# Altium schematic sheet MCU.SchDoc
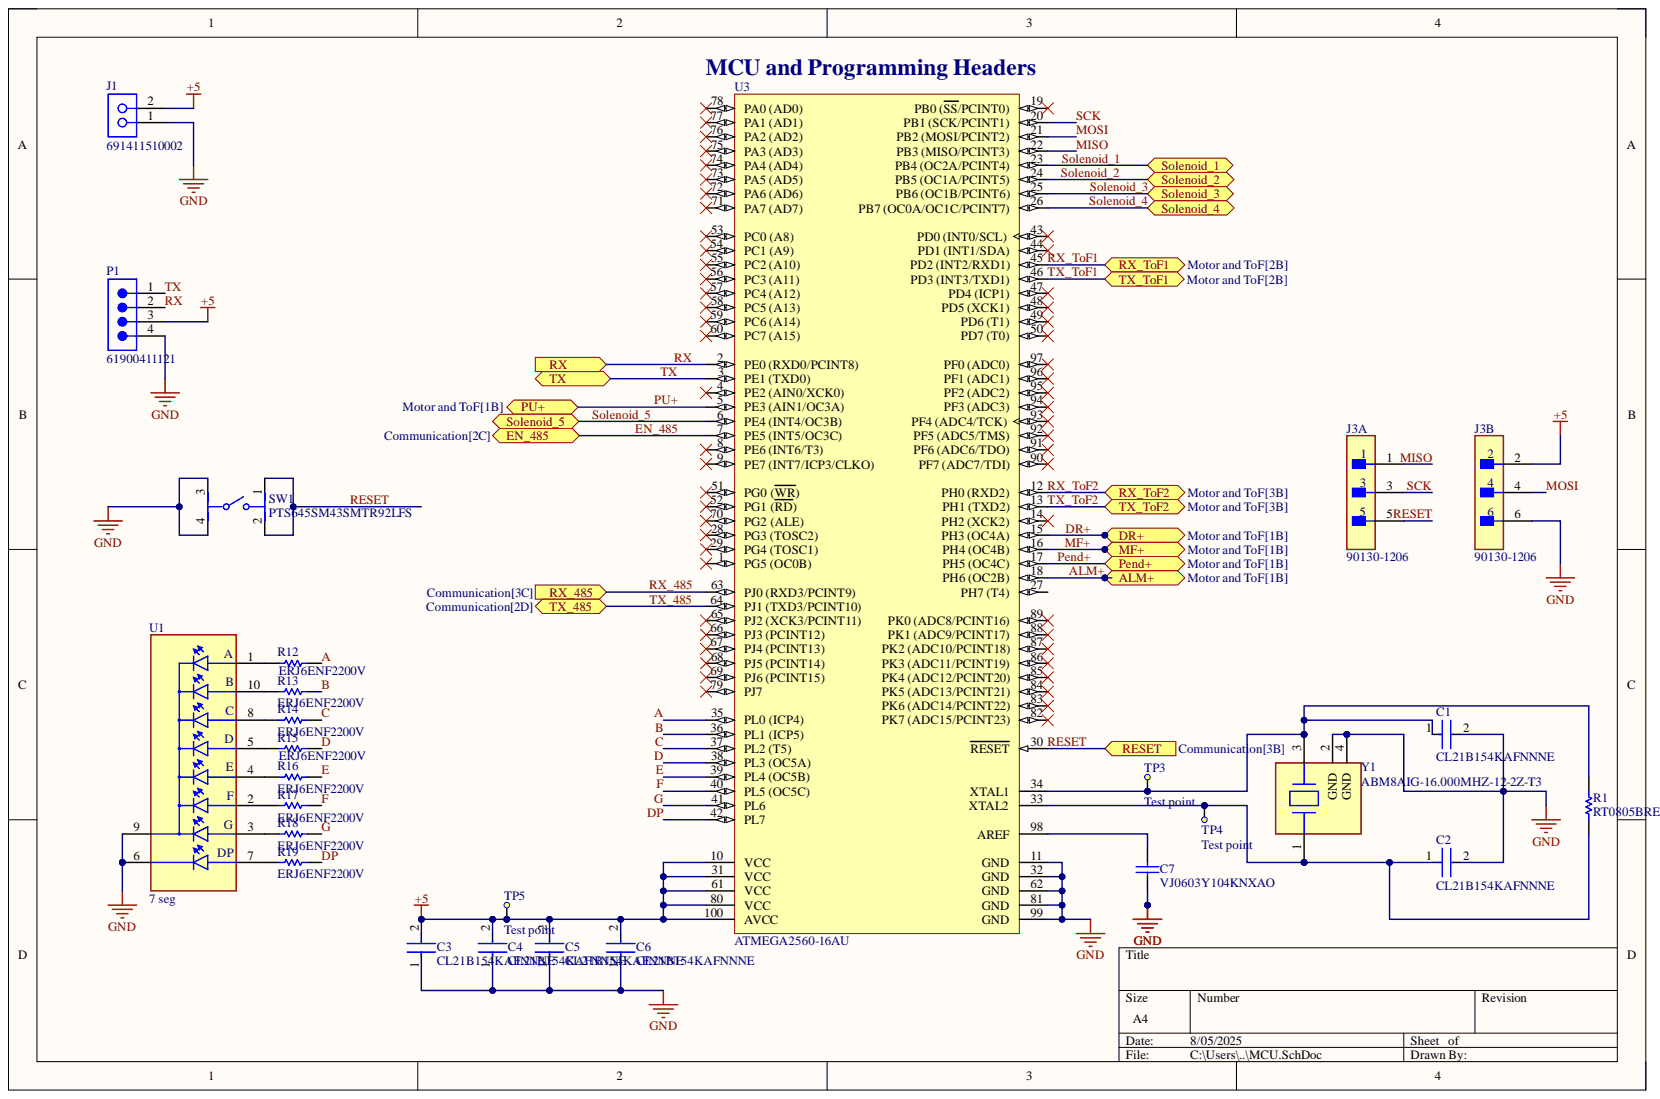
[diagram p0: MCU.SchDoc]

## Parts
C1  CL21B154KAFNNNE  [FP-CL21-IPC_C]
C2  CL21B154KAFNNNE  [FP-CL21-IPC_C]
C3  CL21B154KAFNNNE  [FP-CL21-IPC_C]
C4  CL21B154KAFNNNE  [FP-CL21-IPC_C]
C5  CL21B154KAFNNNE  [FP-CL21-IPC_C]
C6  CL21B154KAFNNNE  [FP-CL21-IPC_C]
C7  VJ0603Y104KNXAO  [CAPC1608X92X38LL15T08]
J1  691411510002  [691411510002]
J3  90130-1206  [FP-90130-1206-MFG]  (parts A,B)
P1  61900411121  [61900411121]
R1  RT0805BRE071ML  [RESC2013X60X35ML10T20]  (value not drawn)
R12  ERJ6ENF2200V  [RESC2013X70X40NL20T20]
R13  ERJ6ENF2200V  [RESC2013X70X40NL20T20]
R14  ERJ6ENF2200V  [RESC2013X70X40NL20T20]
R15  ERJ6ENF2200V  [RESC2013X70X40NL20T20]
R16  ERJ6ENF2200V  [RESC2013X70X40NL20T20]
R17  ERJ6ENF2200V  [RESC2013X70X40NL20T20]
R18  ERJ6ENF2200V  [RESC2013X70X40NL20T20]
R19  ERJ6ENF2200V  [RESC2013X70X40NL20T20]
SW1  PTS645SM43SMTR92LFS  [FP-PTS645SM43SMTR92LFS-MFG]
TP3  Test point  [Test_point]
TP4  Test point  [Test_point]
TP5  Test point  [Test_point]
U1  7 seg  [LED_HDSP-U113]
U3  ATMEGA2560-16AU  [ATML-100A_L]
Y1  ABM8AIG-16.000MHZ-12-2Z-T3  [FP-ABM8AIG-MFG]

## Ports
ALM+, DR+, EN_485, MF+, Pend+, PU+, RESET, RX, RX_485, RX_ToF1, RX_ToF2, Solenoid_1, Solenoid_2, Solenoid_3, Solenoid_4, Solenoid_5, TX, TX_485, TX_ToF1, TX_ToF2

## Nets
+5: C3.2 C4.2 C5.2 C6.2 J1.2 J3.2 P1.3 TP5.TP? U3.10(VCC) U3.31(VCC) U3.61(VCC) U3.80(VCC) U3.100(AVCC)
GND: C1.2 C2.2 C3.1 C4.1 C5.1 C6.1 C7.1 J1.1 J3.6 P1.4 SW1.3 SW1.4 U1.6 U1.9 U3.11(GND) U3.32(GND) U3.62(GND) U3.81(GND) U3.99(GND) Y1.2(GND) Y1.4(GND)
A: R12.2 U3.35(PL0_(ICP4))
ALM+: U3.18(PH6_(OC2B)) ; port ALM+
B: R13.2 U3.36(PL1_(ICP5))
C: R14.2 U3.37(PL2_(T5))
D: R15.2 U3.38(PL3_(OC5A))
DP: R19.2 U3.42(PL7)
DR+: U3.15(PH3_(OC4A)) ; port DR+
E: R16.2 U3.39(PL4_(OC5B))
EN_485: U3.7(PE5_(INT5/OC3C)) ; port EN_485
F: R17.2 U3.40(PL5_(OC5C))
G: R18.2 U3.41(PL6)
MF+: U3.16(PH4_(OC4B)) ; port MF+
MISO: J3.1 U3.22(PB3_(MISO/PCINT3))
MOSI: J3.4 U3.21(PB2_(MOSI/PCINT2))
Pend+: U3.17(PH5_(OC4C)) ; port Pend+
PU+: U3.5(PE3_(AIN1/OC3A)) ; port PU+
RESET: J3.5 SW1.1 SW1.2 U3.30(RESET) ; port RESET
RX: P1.2 U3.2(PE0_(RXD0/PCINT8)) ; port RX
RX_485: U3.63(PJ0_(RXD3/PCINT9)) ; port RX_485
RX_ToF1: U3.45(PD2_(INT2/RXD1)) ; port RX_ToF1
RX_ToF2: U3.12(PH0_(RXD2)) ; port RX_ToF2
SCK: J3.3 U3.20(PB1_(SCK/PCINT1))
Solenoid_1: U3.23(PB4_(OC2A/PCINT4)) ; port Solenoid_1
Solenoid_2: U3.24(PB5_(OC1A/PCINT5)) ; port Solenoid_2
Solenoid_3: U3.25(PB6_(OC1B/PCINT6)) ; port Solenoid_3
Solenoid_4: U3.26 ; port Solenoid_4
Solenoid_5: U3.6(PE4_(INT4/OC3B)) ; port Solenoid_5
TX: P1.1 U3.3(PE1_(TXD0)) ; port TX
TX_485: U3.64(PJ1_(TXD3/PCINT10)) ; port TX_485
TX_ToF1: U3.46(PD3_(INT3/TXD1)) ; port TX_ToF1
TX_ToF2: U3.13(PH1_(TXD2)) ; port TX_ToF2
NetC1_1: C1.1 R1.2 TP3.TP? U3.34(XTAL1) Y1.3
NetC2_1: C2.1 R1.1 TP4.TP? U3.33(XTAL2) Y1.1
NetC7_2: C7.2 U3.98(AREF)
NetR12_1: R12.1 U1.1
NetR13_1: R13.1 U1.10
NetR14_1: R14.1 U1.8
NetR15_1: R15.1 U1.5
NetR16_1: R16.1 U1.4
NetR17_1: R17.1 U1.2
NetR18_1: R18.1 U1.3
NetR19_1: R19.1 U1.7

Not connected: U3 1(PG5_(OC0B)) 4(PE2_(AIN0/XCK0)) 8(PE6_(INT6/T3)) 9(PE7_(INT7/ICP3/CLKO)) 14(PH2_(XCK2)) 19(PB0_(SS/PCINT0)) 27(PH7_(T4)) 28(PG3_(TOSC2)) 29(PG4_(TOSC1)) 43(PD0_(INT0/SCL)) 44(PD1_(INT1/SDA)) 47(PD4_(ICP1)) 48(PD5_(XCK1)) 49(PD6_(T1)) 50(PD7_(T0)) 51(PG0_(WR)) 52(PG1_(RD)) 53(PC0_(A8)) 54(PC1_(A9)) 55(PC2_(A10)) 56(PC3_(A11)) 57(PC4_(A12)) 58(PC5_(A13)) 59(PC6_(A14)) 60(PC7_(A15)) 65(PJ2_(XCK3/PCINT11)) 66(PJ3_(PCINT12)) 67(PJ4_(PCINT13)) 68(PJ5_(PCINT14)) 69(PJ6_(PCINT15)) 70(PG2_(ALE)) 71(PA7_(AD7)) 72(PA6_(AD6)) 73(PA5_(AD5)) 74(PA4_(AD4)) 75(PA3_(AD3)) 76(PA2_(AD2)) 77(PA1_(AD1)) 78(PA0_(AD0)) 79(PJ7) 82(PK7_(ADC15/PCINT23)) 83(PK6_(ADC14/PCINT22)) 84(PK5_(ADC13/PCINT21)) 85(PK4_(ADC12/PCINT20)) 86(PK3_(ADC11/PCINT19)) 87(PK2_(ADC10/PCINT18)) 88(PK1_(ADC9/PCINT17)) 89(PK0_(ADC8/PCINT16)) 90(PF7_(ADC7/TDI)) 91(PF6_(ADC6/TDO)) 92(PF5_(ADC5/TMS)) 93(PF4_(ADC4/TCK)) 94(PF3_(ADC3)) 95(PF2_(ADC2)) 96(PF1_(ADC1)) 97(PF0_(ADC0))

## Library data of the parts
C1-C6 = CMP-13271-001271-1: CL21 Series 0805 150nF 25V ±10% Tolerance X7R Multilayer Ceramic Chip Capacitor; Capacitance: 150nF; Case Code (Imperial): 0805; Case Code (Metric): 2012; Case/Package: 0805; Component Kind: Standard; Depth: 1.25mm; Dielectric: X7R; Height: 1.25mm; Lead Free: Lead Free; Length: 2mm; Library Name: Altium Content Vault; Max Operating Temperature: 125°C; Min Operating Temperature: -55°C; Mount: Surface Mount; Package Quantity: 1; Packaging: Tape and Reel; Radiation Hardening: No; Thickness: 0.053inch; Tolerance: 10%; Voltage Rating: 25V; Voltage Rating (DC): 25V; Width: 0.049inch
C7 = CMP-1035-02588-2: Manufacturer: Vitramon; Component Kind: Standard; Library Name: Altium Content Vault; Part Number: VJ0603Y104KNXAO
J1 = CMP-1502-03078-2: NexarPartId: 105952432; Current Rating: 10A; Number of Contacts: 2; Voltage Rating: 300V; Component Kind: Standard; Height: 14mm; Library Name: Altium Content Vault; Max Operating Temperature: 120°C; Min Operating Temperature: -30°C; Number of Rows: 1
J3 = CMP-04776-000468-1: CONN HEADER VERT 6POS 2.54MM; Contact Resistance: 20mR; Current Rating: 3A; Gender: Male; Glow Wire Compliant: Non-compliant; Insulation Resistance: 5GR; Max Current Rating: 3.9A; Max Voltage Rating (AC): 500V; Max Voltage Rating (DC): 350.35kV; Number of Circuits: 6; Voltage Rating: 350V; Color: Black; Component Kind: Standard; Contact Gender: Male; Contact Material: Brass; Contact Plating: Gold; Depth: 3.94mm; Height: 4.7mm; Housing Color: Black; Housing Material: Polyester; Lead Free: Lead Free; Lead Pitch: 2.54mm; Length: 8mm; Library Name: Altium Content Vault; Material: Glass; Max Operating Temperature: 125°C; Min Operating Temperature: -55°C; Mount: Through Hole; Number of Contacts: 6; Number of Poles: 6; Number of Positions: 6; Number of Rows: 2; Orientation: Vertical; Package Quantity: 2560; Packaging: Tray; Pitch: 2.54mm; Radiation Hardening: No; Row Spacing: 2.54mm; Series: 90130; Termination: Solder; Voltage Rating (AC): 350V; Voltage Rating (DC): 350V; Working Voltage: 350V
P1 = CMP-1502-00586-2: NexarPartId: 18818226; Connector Type: Male Pin; Contact Resistance: 20mΩ; Current Rating: 3A; Gender: Male; Insulation: Insulated; Insulation Resistance: 1GΩ; Number of Contacts: 4; Operating Supply Voltage: 250V; Pitch: 2.54mm; Color: Beige; Component Kind: Standard; Contact Gender: Male; Contact Material: Copper; Contact Mating Length: 7.493mm; Contact Plating: Tin; Fastening Type: Friction; Flammability Rating: UL94 V-0; Height: 3.3mm; Housing Material: Nylon; Lead Free: Lead Free; Lead Pitch: 2.54mm; Length: 10.16mm; Library Name: Altium Content Vault; Max Operating Temperature: 105°C; Min Operating Temperature: -40°C; Mount: Through Hole; Number of Pins: 4; Number of Positions: 4; Number of Rows: 1; Orientation: Vertical; Packaging: Bulk; REACH SVHC: Unknown; RoHS: Compliant; Termination: Through Hole; Voltage Rating: 250V; Width: 10.2mm
R1 = CMP-2001-01491-1: Manufacturer: Yageo; Component Kind: Standard; Library Name: Altium Content Vault; Part Number: RT0805BRE071ML
R12-R19 = CMP-2001-00044-1: Manufacturer: Panasonic; Component Kind: Standard; Library Name: Altium Content Vault; Part Number: ERJ6ENF2200V
SW1 = CMP-2000-05160-2: SWITCH TACTILE SPST-NO 0.05A 12V; Actuator Length: 0.85mm; Actuator Material: Nylon; Actuator Type: Standard; Contact Resistance: 100mR; Current Rating: 50mA; Illumination Type: Non-Illuminated; Mechanical Life: 100000Cycles; Operating Force: 160gf; Switch Function: Off-Mom; Throw Configuration: SPST; Case/Package: SMD/SMT; Color: Black; Component Kind: Standard; Contact Material: Silver; Contact Plating: Silver; Depth: 9mm; Lead Free: Lead Free; Length: 6mm; Library Name: Altium Content Vault; Max Current Rating: 50mA; Max Operating Temperature: 60°C; Max Voltage Rating (DC): 12V; Min Operating Temperature: -20°C; Mount: Surface Mount; Orientation: Straight; Package Quantity: 1; Packaging: Tape and Reel; REACH SVHC: No SVHC; Termination: Gull Wing; Voltage Rating (DC): 12V
TP3-TP5 = test_point: Component Kind: Standard; Library Name: SL1.SchLib; Value: Test point
U1 = HDSP-U113: Display Modules - LED Character and Numeric Red 7-Segment 1 Character Common Cathode 1.7V 5mA 0.433 H x 0.280 W x 0.197 D (11.00mm x 7.10mm x 5.00mm) 10-DIP (0.209, 5.30mm); MF: Avago; Availability: In Stock; Check_prices: https://www.snapeda.com/parts/HDSP-U113/Avago/view-part/?ref=eda; Component Kind: Standard; Library Name: HDSP-U113.IntLib; MP: HDSP-U113; Package: None; Price: None; SnapEDA_Link: https://www.snapeda.com/parts/HDSP-U113/Avago/view-part/?ref=snap
U3 = CMP-020-00013-3: Atmel Microcontroller with 256 KB In-System Programmable Flash, 8-Bit , 4.5 to 5.5 V, -40 to 85 degC, 100-Pin QFP, Pb-Free, Tape and Reel; ADC Channels: 16; Density: 256KB; Ethernet Channels: 0; Frequency: 16MHz; I/Os: 86; Interface: USART; Max Supply Voltage: 5.5V; Min Supply Voltage: 4.5V; Nominal Supply Voltage: 5V; PWM Channels: 12; RAM Size: 8KB; Case/Package: TQFP; Component Kind: Standard; Datasheet URL: http://www.atmel.com/images/atmel-2549-8-bit-avr-microcontroller-atmega640-1280-1281-2560-2561_summary.pdf; Datasheet Version: Feb-14; Manufacturer: Atmel; Manufacturer URL: http://www.atmel.com/; Max Clock Frequency: 16MHz; Max Operating Temperature: 85°C; Min Operating Temperature: -40°C; Mounting Technology: SM; Package Description: 100-Pin Thin Profile Plastic Quad Flat Package, Body 14 x 14 mm, Pitch 0.5 mm; Package Quantity: 90; Package Reference: 100A; Packaging: Tray; Pins: 100; RoHS Compliant: True; USB Channels: 0; Library Name: Raspi Cap
Y1 = CMP-27762-000014-2: Crystal 16MHz ±20ppm (Tol) ±50ppm (Stability) 12pF FUND 70Ω Automotive 4-Pin Ultra Mini-CSMD T/R; ESR (Equivalent Series Resistance): 70R; Case/Package: SMD/SMT; Component Kind: Standard; Frequency: 16MHz; Frequency Stability: 50ppm; Frequency Tolerance: 20ppm; Height: 0.031inch; Length: 0.126inch; Library Name: Altium Content Vault; Load Capacitance: 12pF; Max Operating Temperature: 125°C; Min Operating Temperature: -40°C; Mount: Surface Mount; Packaging: Tape and Reel; Ratings: AEC-Q200; RoHS Compliant: Yes; Width: 0.098inch
